# Altium schematic sheet 12 Micro Bus HDR.SchDoc
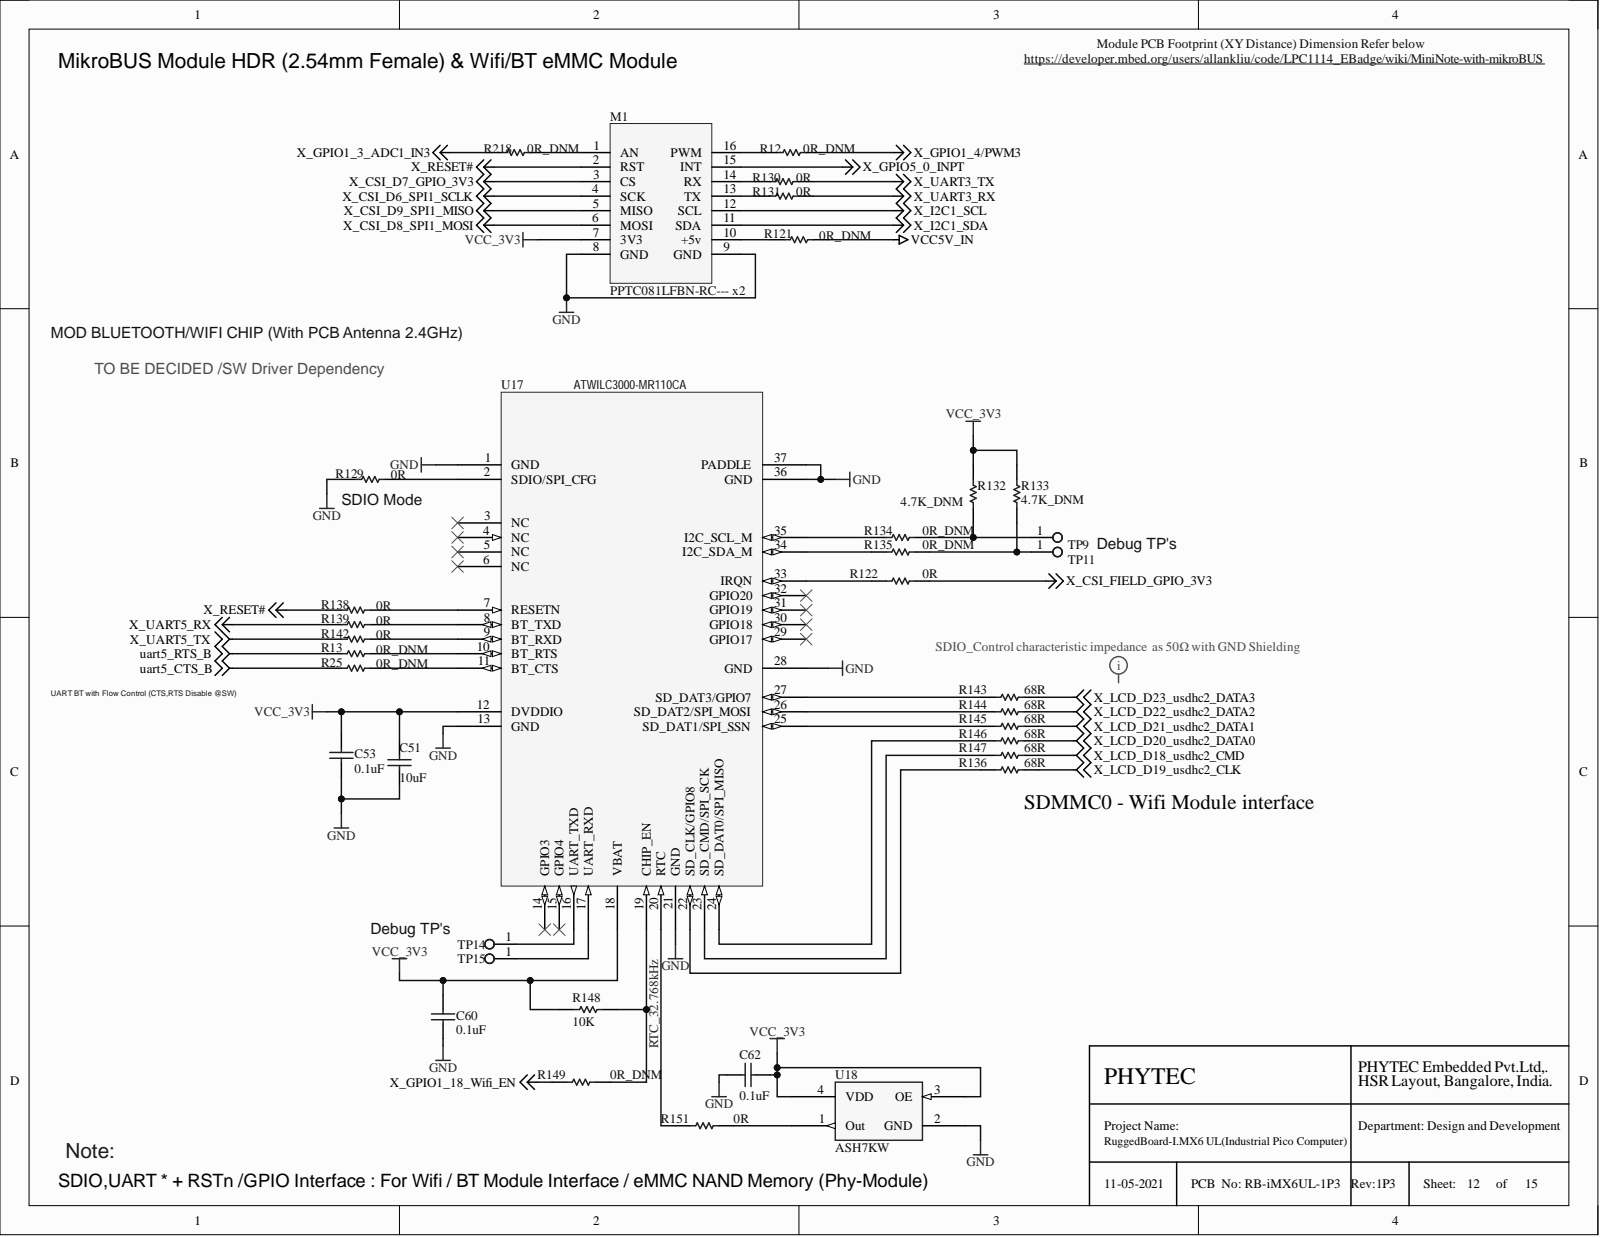
[diagram p0: 12 Micro Bus HDR.SchDoc]

## Parts
C51  10uF  [C0603]
C53  0.1uF  [C0402]
C60  0.1uF  [C0402]
C62  0.1uF  [C0402]
M1  PPTC081LFBN-RC--- x2  [MIKRO_BUS_CON]
R12  0R_DNM  [R0402]
R13  0R_DNM  [R0402]
R25  0R_DNM  [R0402]
R121  0R_DNM  [R0402]
R122  0R  [R0402]
R129  0R  [R0402]
R130  0R  [R0402]
R131  0R  [R0402]
R132  4.7K_DNM  [R0402]
R133  4.7K_DNM  [R0402]
R134  0R_DNM  [R0402]
R135  0R_DNM  [R0402]
R136  68R  [R0402]
R138  0R  [R0402]
R139  0R  [R0402]
R142  0R  [R0402]
R143  68R  [R0402]
R144  68R  [R0402]
R145  68R  [R0402]
R146  68R  [R0402]
R147  68R  [R0402]
R148  10K  [R0402]
R149  0R_DNM  [R0402]
R151  0R  [R0402]
R218  0R_DNM  [R0402]
TP9  Test Point  [TEST POINT PC MINI .040"D YELLOW]  (value not drawn)
TP11  Test Point  [TEST POINT PC MINI .040"D YELLOW]  (value not drawn)
TP14  Test Point  [TEST_POINT_SMD_30D]  (value not drawn)
TP15  Test Point  [TEST_POINT_SMD_30D]  (value not drawn)
U17  WILC3000-MR110CA  value ATWILC3000-MR110CA  MPN: ATWILC3000-MR110CA  [MODULE_ATWILC3000]
U18  value ASH7KW  [XTAL_ASH7KW-32]  (hidden comment: OscWithEnOut)

## Nets
GND: C51.1 C53.1 C60.1 C62.2 M1.8(GND) M1.9(GND) R129.2 U17.1(GND) U17.13(GND) U17.21(GND) U17.28(GND) U17.36(GND) U17.37(PADDLE) U18.2(GND)
uart5_CTS_B: R25.2
uart5_RTS_B: R13.2
VCC5V_IN: R121.1
VCC_3V3: C51.2 C53.2 C60.2 C62.1 M1.7(3V3) R132.2 R133.2 R148.2 U17.12(DVDDIO) U17.18(VBAT) U18.3(OE) U18.4(VDD)
X_CSI_D6_SPI1_SCLK: M1.4(SCK)
X_CSI_D7_GPIO_3V3: M1.3(CS)
X_CSI_D8_SPI1_MOSI: M1.6(MOSI)
X_CSI_D9_SPI1_MISO: M1.5(MISO)
X_CSI_FIELD_GPIO_3V3: R122.2
X_GPIO1_3_ADC1_IN3: R218.2
X_GPIO1_4/PWM3: R12.1
X_GPIO1_18_Wifi_EN: R149.1
X_GPIO5_0_INPT: M1.15(INT)
X_I2C1_SCL: M1.12(SCL)
X_I2C1_SDA: M1.11(SDA)
X_LCD_D18_usdhc2_CMD: R147.1
X_LCD_D19_usdhc2_CLK: R136.1
X_LCD_D20_usdhc2_DATA0: R146.1
X_LCD_D21_usdhc2_DATA1: R145.1
X_LCD_D22_usdhc2_DATA2: R144.1
X_LCD_D23_usdhc2_DATA3: R143.1
X_RESET#: M1.2(RST) R138.2
X_UART3_RX: R131.1
X_UART3_TX: R130.1
X_UART5_RX: R139.2
X_UART5_TX: R142.2
RTC_32.768kHz: R151.1 U17.20(RTC)
NetM1_1: M1.1(AN) R218.1
NetM1_10: M1.10(+5v) R121.2
NetM1_13: M1.13(TX) R131.2
NetM1_14: M1.14(RX) R130.2
NetM1_16: M1.16(PWM) R12.2
NetR13_1: R13.1 U17.10(BT_RTS)
NetR25_1: R25.1 U17.11(BT_CTS)
NetR122_1: R122.1 U17.33(IRQN)
NetR129_1: R129.1 U17.2(SDIO/SPI_CFG)
NetR132_1: R132.1 R134.2 TP9.1
NetR133_1: R133.1 R135.2 TP11.1
NetR134_1: R134.1 U17.35(I2C_SCL_M)
NetR135_1: R135.1 U17.34(I2C_SDA_M)
NetR136_2: R136.2 U17.22(SD_CLK/GPIO8)
NetR138_1: R138.1 U17.7(RESETN)
NetR139_1: R139.1 U17.8(BT_TXD)
NetR142_1: R142.1 U17.9(BT_RXD)
NetR143_2: R143.2 U17.27(SD_DAT3/GPIO7)
NetR144_2: R144.2 U17.26(SD_DAT2/SPI_MOSI)
NetR145_2: R145.2 U17.25(SD_DAT1/SPI_SSN)
NetR146_2: R146.2 U17.24(SD_DAT0/SPI_MISO)
NetR147_2: R147.2 U17.23(SD_CMD/SPI_SCK)
NetR148_1: R148.1 R149.2 U17.19(CHIP_EN)
NetR151_2: R151.2 U18.1(Out)
NetTP14_1: TP14.1 U17.16(UART_TXD)
NetTP15_1: TP15.1 U17.17(UART_RXD)

Not connected: U17 3(NC) 4(NC) 5(NC) 6(NC) 14(GPIO3) 15(GPIO4) 29(GPIO17) 30(GPIO18) 31(GPIO19) 32(GPIO20)

## Library data of the parts
C51 = Cap: CAP CER 10UF 16V X5R 0603; ASSEMBLY_TYPE: SMT; MACRO: Mini PCIe CONN; MFG: Murata; MFG_PN: GRM188R61C106KAALD; Component Kind: Standard; Library Name: Miscellaneous Devices.IntLib; PACKAGE: 0603
C53 C60 C62 = Cap: CAP CER 0.1UF 50V X7R 0402; ASSEMBLY_TYPE: SMT; MFG: Murata; MFG_PN: GRM155R71H104ME14D; Component Kind: Standard; Library Name: Miscellaneous Devices.IntLib; PACKAGE: 0402; Signal Integrity: Cap; Simulation: CAP
M1 = Mikcro_Bus Module: Micro Module Dual Column Female Header 2.54MM; MFG: SULLEN; Component Kind: Standard; Library Name: Schlib1.SchLib; MFG_PN: PPTC081LFBN-RC
R12 R130 R131 R149 R151 R218 = Res1: RES 0 OHM JUMPER 1/16W 0402; ASSEMBLY_TYPE: SMT; MFG: Stackpole Electronics Inc.; MFG_PN: RMCF0402ZT0R00; Component Kind: Standard; Library Name: Miscellaneous Devices.IntLib; PACKAGE: 0402
R13 R25 R121 R122 R129 R134 R135 R138 R139 R142 = Res1: RES SMD 0.0OHM JUMPER 1/16W 0402; ASSEMBLY_TYPE: SMT; MFG: YAGE0; MFG_PN: RC0402JR-070RL; Component Kind: Standard; Library Name: Miscellaneous Devices.IntLib; PACKAGE: 0402; Signal Integrity: Res; Simulation: RESISTOR
R132 R133 = Res1: RES SMD 4.7K OHM 1% 1/16W 0402; ASSEMBLY_TYPE: SMT; KHK_AN: RM938; MACRO: Ethernet PHY Transceiver; MFG: YAGEO; MFG_PN: RC0402FR-074K7L; Component Kind: Standard; Library Name: Miscellaneous Devices.IntLib; PACKAGE: 0402; Signal Integrity: Res; Simulation: RESISTOR; TEMPERATURE: -55°C ~ 155°C
R136 R143-R147 = Res1: RES SMD 68 OHM 1% 1/16W 0402; ASSEMBLY_TYPE: SMT; MFG: YAGEO; MFG_PN: RC0402FR-0768RL; Component Kind: Standard; Library Name: Miscellaneous Devices.IntLib; PACKAGE: 0402; Signal Integrity: Res; Simulation: RESISTOR
R148 = Res1: RES SMD 10K OHM 1% 1/16W 0402; ASSEMBLY_TYPE: SMT; MACRO: Mini PCIe CONN; MFG: YAGEO; MFG_PN: RC0402FR-0710KL; Component Kind: Standard; Library Name: Miscellaneous Devices.IntLib; PACKAGE: 0402; Signal Integrity: Res; Simulation: RESISTOR
TP9 TP11 = TP: TEST POINT PC MINI .040"D RED; ASSEMBLY_TYPE: TH; MACRO: Power Management (VYS, 5V, 3.3V and Reset); MFG: Keystone; MFG_PN: 5000; Component Kind: Standard; PACKAGE: Assembly; TEMPERATURE: -55°C ~ 155°C; Library Name: HTT SoM - Sept 7 2016 V1P2 .SCHLIB
TP14 TP15 = TP: TEST POINT PC MINI .040"D RED; ASSEMBLY_TYPE: SMD; Component Kind: Standard; PACKAGE: Assembly; Library Name: HTT SoM - Sept 7 2016 V1P2 .SCHLIB
U17 = A06-1357: IEEE 802.11 b/g/n Link Controller with Integrated Bluetooth 4.0, PCB Antenna; Manufacturer: ATMEL; PartGroup: Integrated Circuits,Modules,Wifi Module; ComponentStatus: 'Active (verified)'; Database Table Name: AltiumComponents; TemperatureRange: -40Â°C to +85Â°C; AN: A06-1357; Component Kind: Standard; FootprintStatus: 'Active (Verified)'; Library Name: AltiumDbLib.DbLib
U18 = A07-0124: 32.768kHz LOW POWER SMD CRYSTAL OSCILLATOR; Manufacturer: ABRACON; PartGroup: Frequency devices,Oscillators; ComponentStatus: 'Active (verified)'; Database Table Name: AltiumComponents; MFG_PN: ASH7KW-32.768kHz-L-T; TemperatureRange: -40 to 85; AN: A07-0124; Component Kind: Standard; FootprintStatus: 'New (Not verified)'; Height: 1; Library Name: AltiumDbLib.DbLib; MPN: ASH7KW-32.768kHz-L-T
